# Altium schematic sheet MCU.SchDoc(MCU)
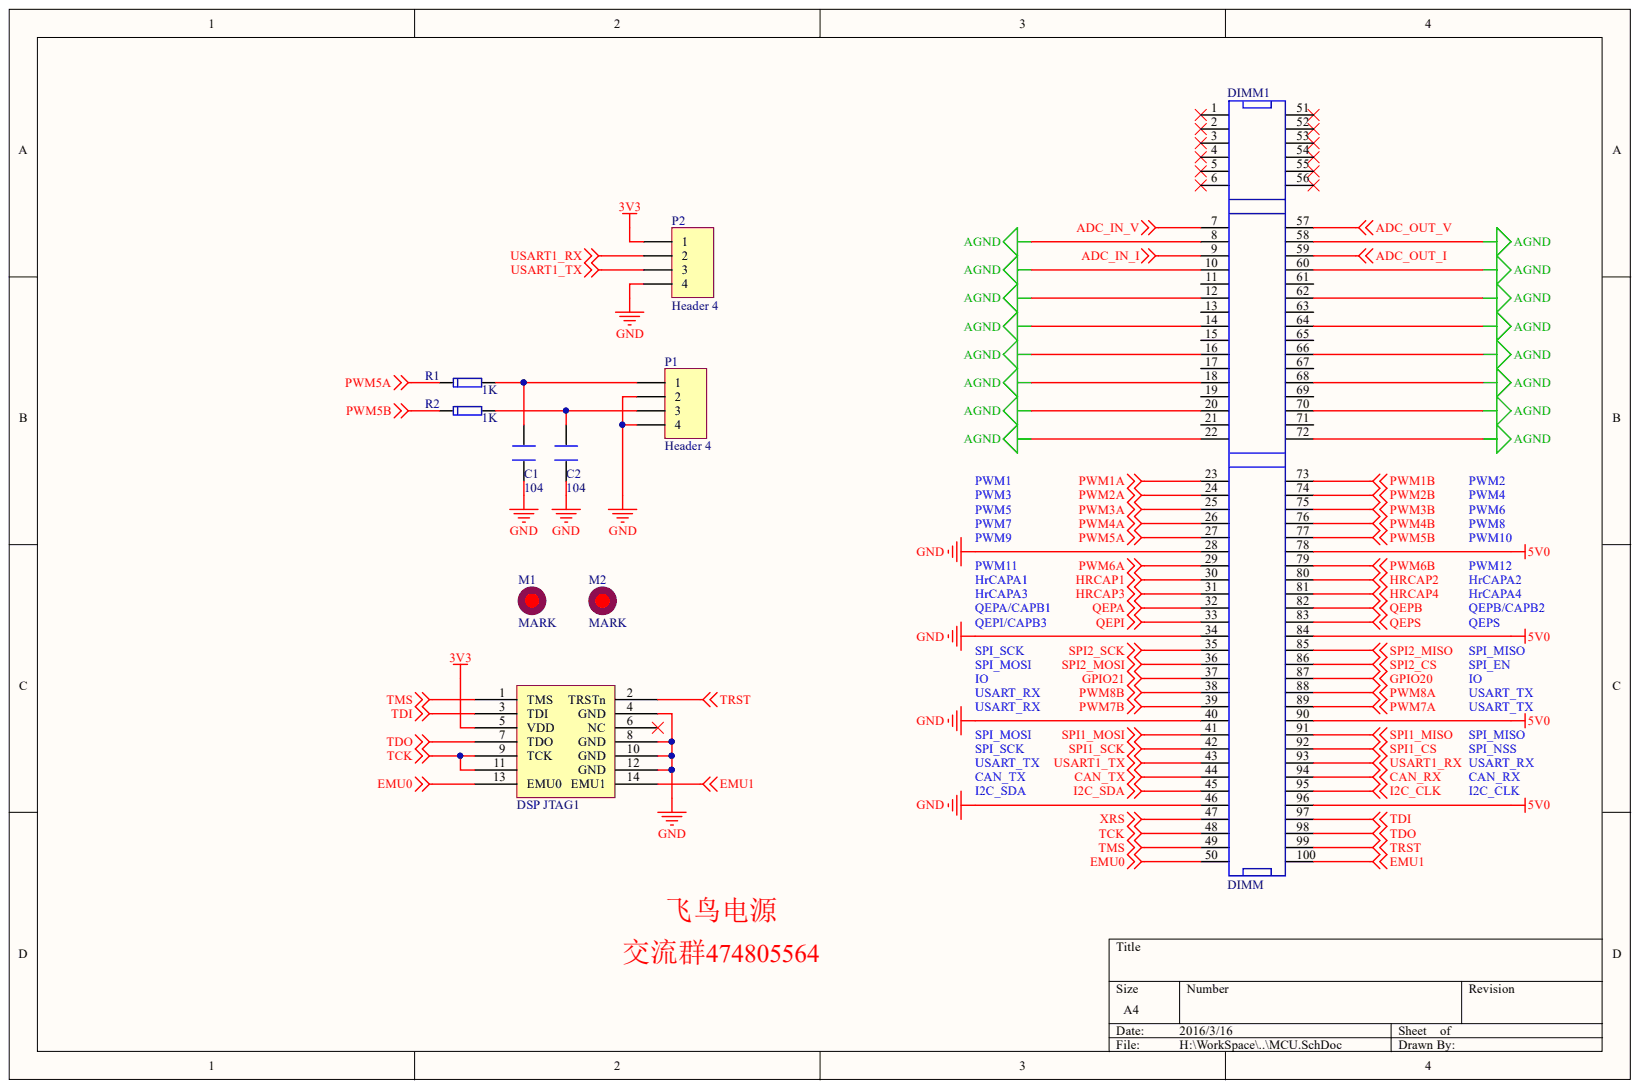
[diagram p0: MCU.SchDoc]

## Parts
C1  104  [C0603]
C2  104  [C0603]
DIMM1  DIMM  [DIMM100]
DSP_JTAG1  DSP_JTAG14  [DSP_JTAG14]  (value not drawn)
M1  MARK  [MARK]  (no BOM)
M2  MARK  [MARK]  (no BOM)
P1  Header 4  [HDR1X4]
P2  Header 4  [HDR1X4]
R1  1K  [R0603]
R2  1K  [R0603]

## Nets
3V3: DSP_JTAG1.5(VDD) P2.1
5V0: DIMM1.78 DIMM1.84 DIMM1.90 DIMM1.96
ADC_IN_I: DIMM1.9
ADC_IN_V: DIMM1.7
ADC_OUT_I: DIMM1.59
ADC_OUT_V: DIMM1.57
AGND: DIMM1.8 DIMM1.10 DIMM1.12 DIMM1.14 DIMM1.16 DIMM1.18 DIMM1.20 DIMM1.22 DIMM1.58 DIMM1.60 DIMM1.62 DIMM1.64 DIMM1.66 DIMM1.68 DIMM1.70 DIMM1.72
EMU0: DIMM1.50 DSP_JTAG1.13(EMU0)
EMU1: DIMM1.100 DSP_JTAG1.14(EMU1)
GND: C1.2 C2.2 DIMM1.28 DIMM1.34 DIMM1.40 DIMM1.46 DSP_JTAG1.4(GND) DSP_JTAG1.8(GND) DSP_JTAG1.10(GND) DSP_JTAG1.12(GND) P1.2 P1.4 P2.4
GPIO20: DIMM1.87
GPIO21: DIMM1.37
HRCAP1: DIMM1.30
HRCAP2: DIMM1.80
HRCAP3: DIMM1.31
HRCAP4: DIMM1.81
PWM1A: DIMM1.23
PWM1B: DIMM1.73
PWM2A: DIMM1.24
PWM2B: DIMM1.74
PWM3A: DIMM1.25
PWM3B: DIMM1.75
PWM4A: DIMM1.26
PWM4B: DIMM1.76
PWM5A: DIMM1.27 R1.1
PWM5B: DIMM1.77 R2.1
PWM6A: DIMM1.29
PWM6B: DIMM1.79
PWM7A: DIMM1.89
PWM7B: DIMM1.39
PWM8A: DIMM1.88
PWM8B: DIMM1.38
QEPA: DIMM1.32
QEPB: DIMM1.82
QEPI: DIMM1.33
QEPS: DIMM1.83
SPI1_CS: DIMM1.92
SPI1_MISO: DIMM1.91
SPI1_MOSI: DIMM1.41
SPI1_SCK: DIMM1.42
SPI2_CS: DIMM1.86
SPI2_MISO: DIMM1.85
SPI2_MOSI: DIMM1.36
SPI2_SCK: DIMM1.35
TCK: DIMM1.48 DSP_JTAG1.9(TCK) DSP_JTAG1.11
TDI: DIMM1.97 DSP_JTAG1.3(TDI)
TDO: DIMM1.98 DSP_JTAG1.7(TDO)
TMS: DIMM1.49 DSP_JTAG1.1(TMS)
TRST: DIMM1.99 DSP_JTAG1.2(TRSTn)
USART1_RX: DIMM1.93 P2.2
USART1_TX: DIMM1.43 P2.3
XRS: DIMM1.47
CAN_RX: DIMM1.94
CAN_TX: DIMM1.44
I2C_CLK: DIMM1.95
I2C_SDA: DIMM1.45
NetC1_1: C1.1 P1.1 R1.2
NetC2_1: C2.1 P1.3 R2.2
SPI_MISO:
SPI_MOSI:
SPI_NSS:
SPI_SCK:
USART_RX:
USART_TX:

Not connected: DIMM1 1 2 3 4 5 6 11 13 15 17 19 21 51 52 53 54 55 56 61 63 65 67 69 71; DSP_JTAG1 6(NC); M1 1; M2 1

## Library data of the parts
C1 C2 = Capacitor: Component Kind: Standard; Library Name: PP_SCH.SchLib
DIMM1 = DIMM100: Component Kind: Standard; Library Name: PP_SCH.SchLib
M1 M2 = MARK: 视觉定位点; Library Name: PP_SCH.SchLib
P1 P2 = Header 4: Header, 4-Pin; Component Kind: Standard; LatestRevisionDate: 17-Jul-2002; LatestRevisionNote: Re-released for DXP Platform.; Library Name: Miscellaneous Connectors.SchLib; Signal Integrity: Connector; Publisher: Altium Limited
R1 R2 = Resistor: Component Kind: Standard; Library Name: PP_SCH.SchLib
DSP_JTAG1 = DSP_JTAG14: Component Kind: Standard; Library Name: PP_SCH.SchLib
